# Altium schematic sheet ADF4351廉价版.SchDoc
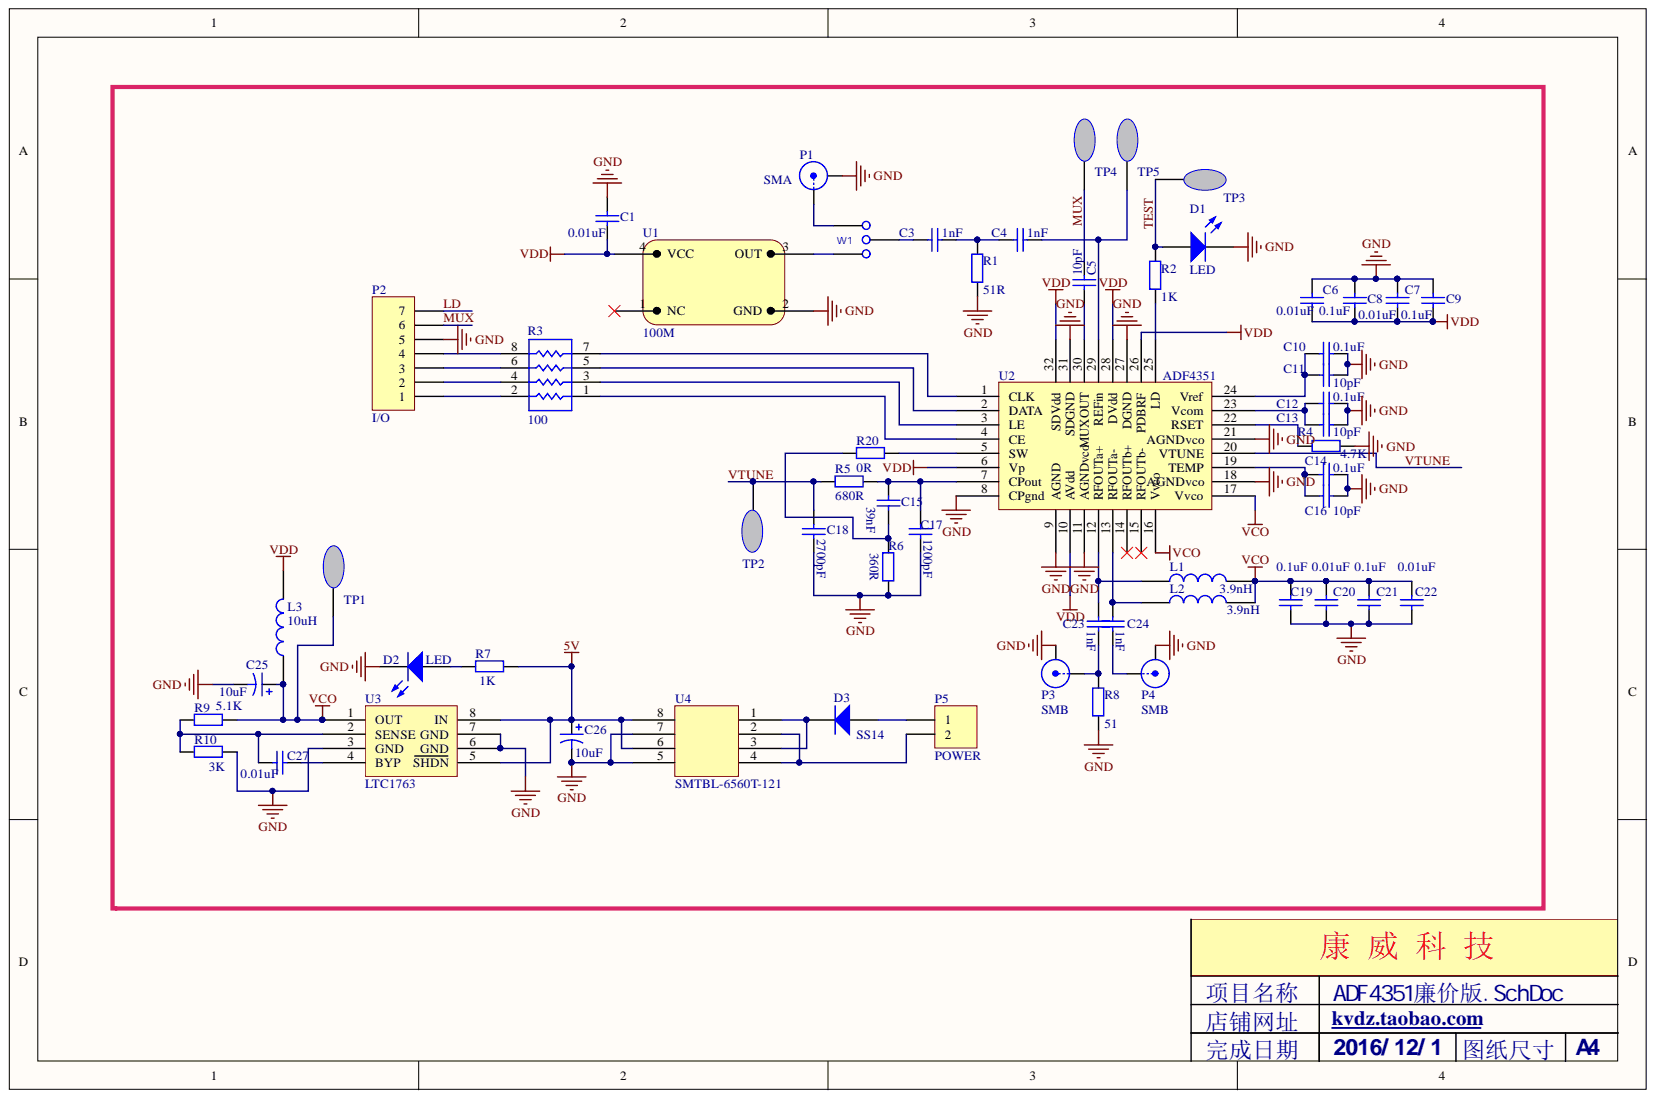
[diagram p0: ADF4351廉价版.SchDoc]

## Parts
C1  value 0.01uF  [C0603]
C3  value 1nF  [C0603]
C4  value 1nF  [C0603]
C5  value 10pF  [C0603]
C6  value 0.01uF  [C0603]
C7  value 0.01uF  [C0603]
C8  value 0.1uF  [C0603]
C9  value 0.1uF  [C0603]
C10  value 0.1uF  [C0603]
C11  value 10pF  [C0603]
C12  value 0.1uF  [C0603]
C13  value 10pF  [C0603]
C14  value 0.1uF  [C0603]
C15  value 39nF  [C0603]
C16  value 10pF  [C0603]
C17  value 1200pF  [C0603]
C18  value 2700pF  [C0603]
C19  value 0.1uF  [C0603]
C20  value 0.01uF  [C0603]
C21  value 0.1uF  [C0603]
C22  value 0.01uF  [C0603]
C23  value 1nF  [C0603]
C24  value 1nF  [C0603]
C25  value 10uF  [C3528]
C26  value 10uF  [C3528]
C27  value 0.01uF  [C0603]
D1  LED  [LED0805G]
D2  LED  [LED0805R]
D3  SS14  [SOD123]
L1  3.9nH  [L0603]
L2  3.9nH  [L0603]
L3  10uH  [L0603]
P1  SMA  [SM]
P2  I/O  [HDR1X7]
P3  SMB  [SM]
P4  SMB  [SM]
P5  POWER  [3.81-2p]
R1  value 51R  [R0603]
R2  value 1K  [R0603]
R3  100  [RA4]
R4  value 4.7K  [R0603]
R5  value 680R  [R0603]
R6  value 360R  [R0603]
R7  value 1K  [R0603]
R8  value 51  [R0603]
R9  value 5.1K  [R0603]
R10  value 3K  [R0603]
R20  value 0R  [R0603]
TP1  TEST  [Test]  (value not drawn)
TP2  TEST  [Test]  (value not drawn)
TP3  TEST  [Test]
TP4  TEST  [Test]  (value not drawn)
TP5  TEST  [Test]
U1  100M  [power_JINZHEN]
U2  ADF4351  [ADF4350/4351]
U3  LTC1763  [SOP8]
U4  SMTBL-6560T-121  [SOP8]
W1  key  [HDR1X3]  (value not drawn)

## Nets
5V: C26.1 R7.2 U3.5(SHDN) U3.8(IN) U4.6 U4.8
GND: C1.2 C6.1 C7.1 C8.1 C9.1 C10.1 C11.1 C12.1 C13.1 C14.1 C16.1 C17.2 C18.2 C19.1 C20.1 C21.1 C22.1 C25.2 C26.2 D1.2 D2.2 P1.2 P2.5 P3.2 P4.2 R1.1 R4.2 R6.1 R8.1 R10.1 U1.2(GND) U2.8(CPgnd) U2.9(AGND) U2.11(AGNDvco) U2.18(AGNDvco) U2.21(AGNDvco) U2.27(DGND) U2.31(SDGND) U3.3(GND) U3.6(GND) U3.7(GND) U4.5 U4.7
VCO: C19.2 C20.2 C21.2 C22.2 C25.1 L1.2 L2.2 L3.1 R9.2 TP1.1 U2.16(Vvco) U2.17(Vvco) U3.1(OUT)
VDD: C1.1 C6.2 C7.2 C8.2 C9.2 L3.2 U1.4(VCC) U2.6(Vp) U2.10(AVdd) U2.26(PDBRF) U2.28(DVdd) U2.32(SDVdd)
LD: P2.7
MUX: C5.1 P2.6 TP4.1
TEST: D1.1 R2.2 TP3.1
VTUNE: C18.1 R5.1 TP2.1 U2.20(VTUNE)
NetC3_1: C3.1 C4.2 R1.2
NetC3_2: C3.2 W1.2
NetC4_1: C4.1 TP5.1 U2.29(REFin)
NetC5_2: C5.2 U2.30(MUXOUT)
NetC10_2: C10.2 C11.2 U2.24(Vref)
NetC12_2: C12.2 C13.2 U2.23(Vcom)
NetC14_2: C14.2 C16.2 U2.19(TEMP)
NetC15_1: C15.1 C17.1 R5.2 U2.7(CPout)
NetC15_2: C15.2 R6.2 R20.1
NetC23_1: C23.1 L1.1 U2.12(RFOUTa+)
NetC23_2: C23.2 P3.1 R8.2
NetC24_1: C24.1 L2.1 U2.13(RFOUTa-)
NetC24_2: C24.2 P4.1
NetC27_1: C27.1 U3.4(BYP)
NetC27_2: C27.2 R9.1 R10.2 U3.2(SENSE)
NetD2_1: D2.1 R7.1
NetD3_1: D3.1 P5.1
NetD3_2: D3.2 U4.1 U4.3
NetP1_1: P1.1 W1.1
NetP2_1: P2.1 R3.2
NetP2_2: P2.2 R3.4
NetP2_3: P2.3 R3.6
NetP2_4: P2.4 R3.8
NetP5_2: P5.2 U4.2 U4.4
NetR2_1: R2.1 U2.25(LD)
NetR3_1: R3.1 U2.4(CE)
NetR3_3: R3.3 U2.3(LE)
NetR3_5: R3.5 U2.2(DATA)
NetR3_7: R3.7 U2.1(CLK)
NetR4_1: R4.1 U2.22(RSET)
NetR20_2: R20.2 U2.5(SW)
NetU1_3: U1.3(OUT) W1.3

Not connected: U1 1(NC); U2 14(RFOUTb+) 15(RFOUTb-)

## Library data of the parts
C1 C3-C24 C27 = Cap: Capacitor; Component Kind: Standard; LatestRevisionDate: 17-Jul-2002; LatestRevisionNote: Re-released for DXP Platform.; Library Name: Miscellaneous Devices.IntLib; PackageDescription: Radial Cap, Thru-Hole; 2 Leads; 0.3 in Pin Spacing; PackageReference: RAD-0.3; Published: 8-Jun-2000; Signal Integrity: Cap; Simulation: CAP; Publisher: Altium Limited
C25 C26 = Cap Pol1: Polarized Capacitor (Radial); Component Kind: Standard; LatestRevisionDate: 17-Jul-2002; LatestRevisionNote: Re-released for DXP Platform.; Library Name: Miscellaneous Devices.IntLib; PackageDescription: Polarized Capacitor (Radial); 2 Leads; PackageReference: RB7.6-15; Published: 8-Jun-2000; Signal Integrity: Cap Pol; Simulation: CAP; Publisher: Altium Limited
D3 = Diode 1N4007: 1 Amp General Purpose Rectifier; Code_JEDEC: DO-204-AL; Component Kind: Standard; ComponentLink1Description: Manufacturer Link; ComponentLink1URL: http://www.fairchildsemi.com/; ComponentLink2Description: Datasheet; ComponentLink2URL: http://www.fairchildsemi.com/ds/1N%2F1N4001.pdf; DatasheetVersion: 1998; LatestRevisionDate: 17-Jul-2002; LatestRevisionNote: Re-released for DXP Platform.; Library Name: Miscellaneous Devices.IntLib; PackageDescription: Diode, Axial; Body 5.2 x 2.7 mm (LxDia. max), Lead Dia. 0.86 mm (max); PackageReference: DO-41; PackageVersion: Aug-1999; Published: 8-Jun-2000; Signal Integrity: 1N4007; Simulation: 1N4007; Publisher: Altium Limited
L1 L2 = L_10UH: L_10UH/SL1206; Text Field1: 10UH; Component Kind: Standard
L3 = L_10UH: L_10UH/SL1206; Component Kind: Standard; Text Field1: 10UH
P1 P3 P4 = SMB: SMB Straight Connector; Component Kind: Standard; LatestRevisionDate: 17-Jul-2002; LatestRevisionNote: Re-released for DXP Platform.; Library Name: Miscellaneous Devices.SchLib; Signal Integrity: Connector; Publisher: Altium Limited
P2 = Header 7: Header, 7-Pin; Component Kind: Standard; LatestRevisionDate: 17-Jul-2002; LatestRevisionNote: Re-released for DXP Platform.; Signal Integrity: Connector; Library Name: Xiaowei.SchLib; Publisher: Altium Limited
P5 = Header 2: Header, 2-Pin; Component Kind: Standard; LatestRevisionDate: 17-Jul-2002; LatestRevisionNote: Re-released for DXP Platform.; Signal Integrity: Connector; Library Name: Xiaowei.SchLib; Publisher: Altium Limited
R1 R2 R4-R10 = Res2: Resistor; Component Kind: Standard; LatestRevisionDate: 17-Jul-2002; LatestRevisionNote: Re-released for DXP Platform.; Library Name: Miscellaneous Devices.IntLib; PackageDescription: Axial Device, Thru-Hole; 2 Leads; 0.4 in Pin Spacing; PackageReference: AXIAL-0.4; Published: 8-Jun-2000; Signal Integrity: Res; Simulation: RESISTOR; Publisher: Altium Limited
R3 = Res Pack5: Component Kind: Standard; Library Name: Miscellaneous Devices.SchLib
R20 = Res2: Resistor; Value: 0R; Component Kind: Standard; LatestRevisionDate: 17-Jul-2002; LatestRevisionNote: Re-released for DXP Platform.; Library Name: Miscellaneous Devices.IntLib; PackageDescription: Axial Device, Thru-Hole; 2 Leads; 0.4 in Pin Spacing; PackageReference: AXIAL-0.4; Published: 8-Jun-2000; Signal Integrity: Res; Simulation: RESISTOR; Publisher: Altium Limited
TP1-TP5 = 测试口: Component Kind: Standard; Library Name: Xiaowei.SchLib
U1 = CRYS2: Component Kind: Standard
U3 = LTC1763-SOP8: Component Kind: Standard; Library Name: Xiaowei.SchLib
U4 = 贴片共模电感: Component Kind: Standard; Library Name: Xiaowei.SchLib
W1 = W: KEY 1 to 2; Component Kind: Standard
D1 D2 = LED: Component Kind: Standard; LatestRevisionDate: 08-Jul-2005; LatestRevisionNote: Mech Layer 1 removed.; PackageDescription: LED; 2 Leads; PackageReference: LED-0; Published: 8-Jun-2000; Library Name: Xiaowei.SchLib; Publisher: Altium Limited
U2 = ADF4351: Component Kind: Standard; Library Name: Xiaowei.SchLib
